# Altium schematic sheet Regulators.SchDoc(Regulators)
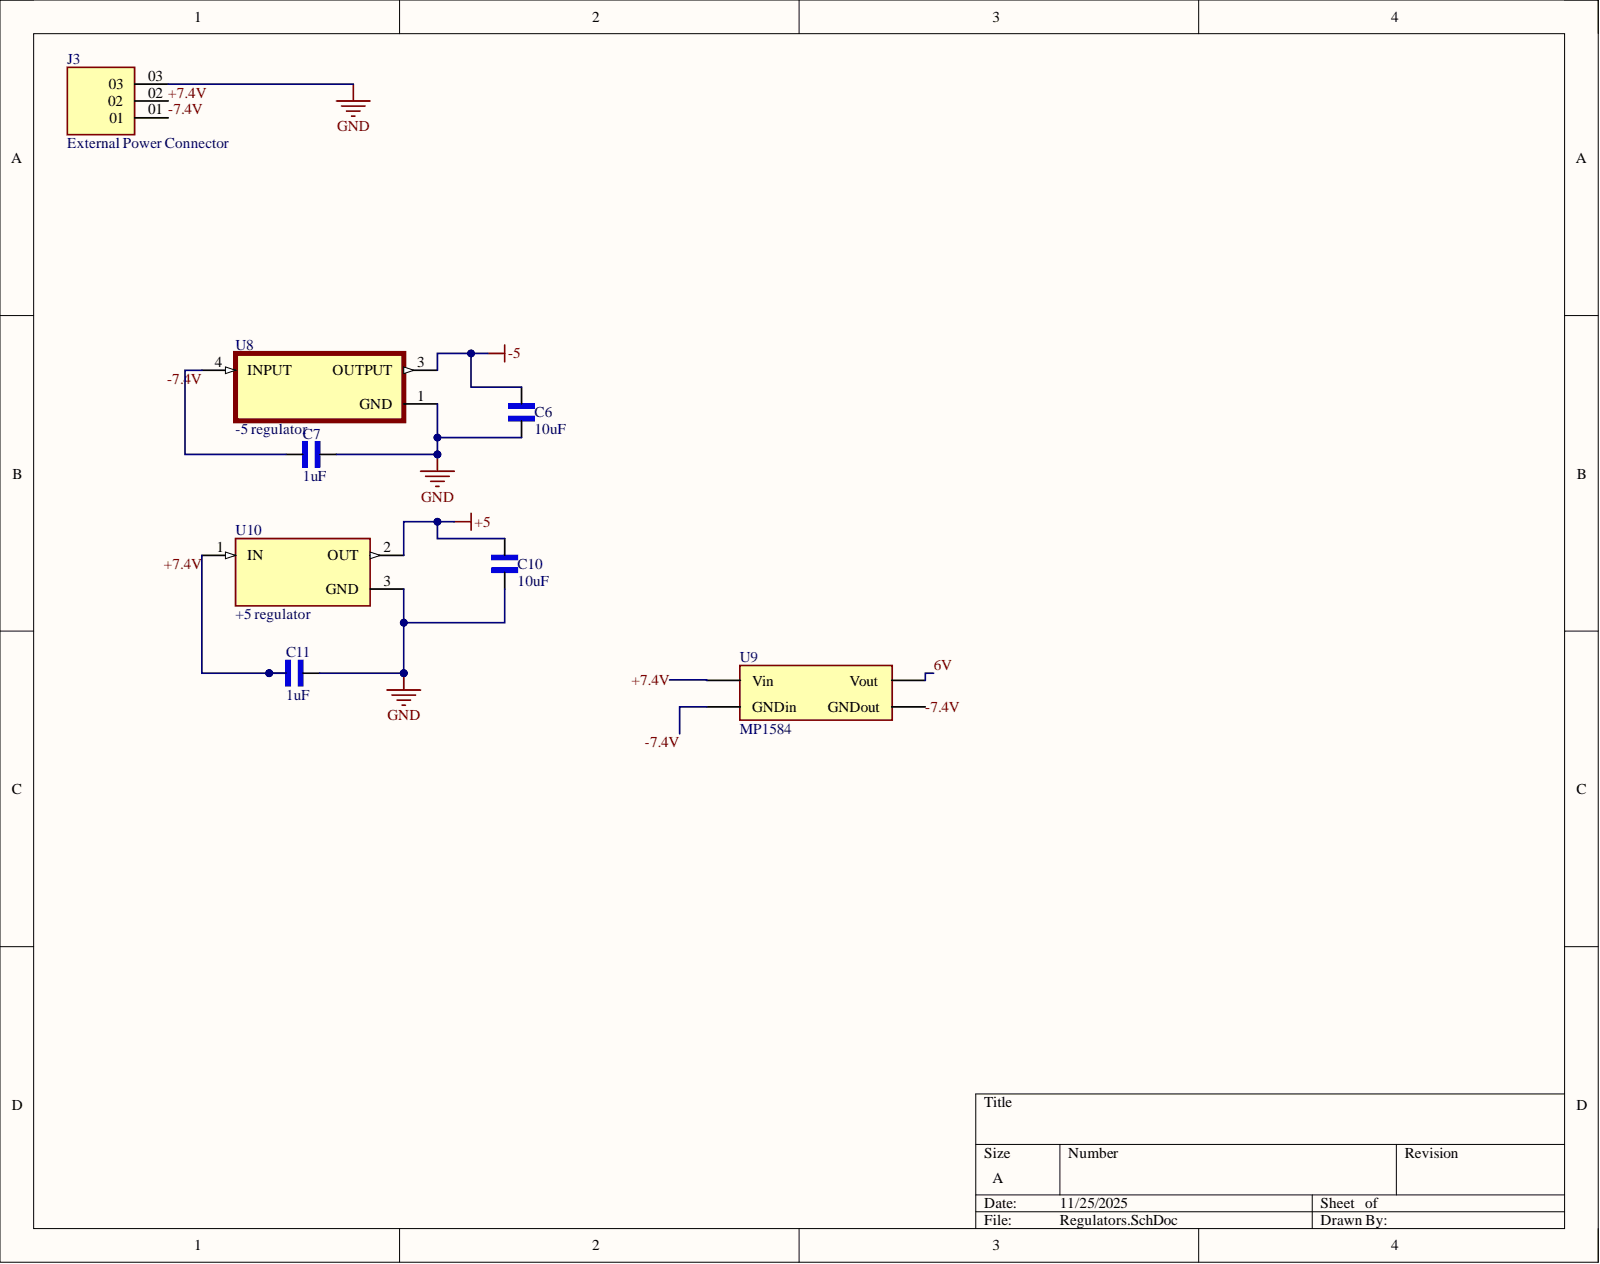
[diagram p0: Regulators.SchDoc]

## Parts
C6  10uF
C7  1uF
C10  10uF
C11  1uF
J3  External Power Connector
U8  -5 regulator
U9  MP1584
U10  +5 regulator

## Nets
+5: C10.2 U10.2
-5: C6.1 U8.3
GND: C6.2 C7.1 C10.1 C11.1 J3.03 U8.1 U10.3
6V: U9.Vout
+7.4V: C11.2 J3.02 U9.Vin U10.1
-7.4V: C7.2 J3.01 U8.4 U9.GNDin U9.GNDout

## Library data of the parts
C6 C7 C10 C11 = 1uF: SMD Capacitor X7R(EIA) with Capacitance: 1.0uF Tol. 10%. Rated Voltage: 25Vdc; GRM21BR71E105KA99L; MF: Murata; Check_prices: https://www.snapeda.com/parts/GRM21BR71E105KA99L/Murata/view-part/?ref=eda; Component Kind: Standard; MP: GRM21BR71E105KA99L; Package: 2012 Murata; SnapEDA_Link: https://www.snapeda.com/parts/GRM21BR71E105KA99L/Murata/view-part/?ref=snap; Library Name: 1ufs.IntLib
J3 = HTSW-103-XX-X-S: MF: Samtec; Availability: Unavailable; Component Kind: Standard; Description: HTSW-103-XX-X-S; Library Name: 3 pin connector.IntLib; MP: HTSW-103-XX-X-S; Package: None; Price: None
U8 = -5 regulator: Linear Voltage Regulator IC Negative Fixed 1 Output 1.5A D2PAK; L7905CD2T-TR; MF: STMicroelectronics; Check_prices: https://www.snapeda.com/parts/L7905CD2T-TR/STMicroelectronics/view-part/?ref=eda; Component Kind: Standard; Library Name: -5 regulator.IntLib; MP: L7905CD2T-TR; Package: TO-263-3 STMicroelectronics; SnapEDA_Link: https://www.snapeda.com/parts/L7905CD2T-TR/STMicroelectronics/view-part/?ref=snap
U10 = +5 regulator: Linear Voltage Regulator IC Positive Fixed 1 Output 1.5A D2PAK; L7805CD2T-TR; MF: STMicroelectronics; Check_prices: https://www.snapeda.com/parts/L7805CD2T-TR/STMicroelectronics/view-part/?ref=eda; Component Kind: Standard; Library Name: +5 regulator.IntLib; MP: L7805CD2T-TR; Package: TO-263-3 STMicroelectronics; SnapEDA_Link: https://www.snapeda.com/parts/L7805CD2T-TR/STMicroelectronics/view-part/?ref=snap
U9 = MP1584: Component Kind: Standard; Library Name: Integrated_Library_pumchi_musikaya.IntLib
